# Altium schematic sheet Accel, Pull up&down Res.SchDoc("Accel, Pull up&down Res")
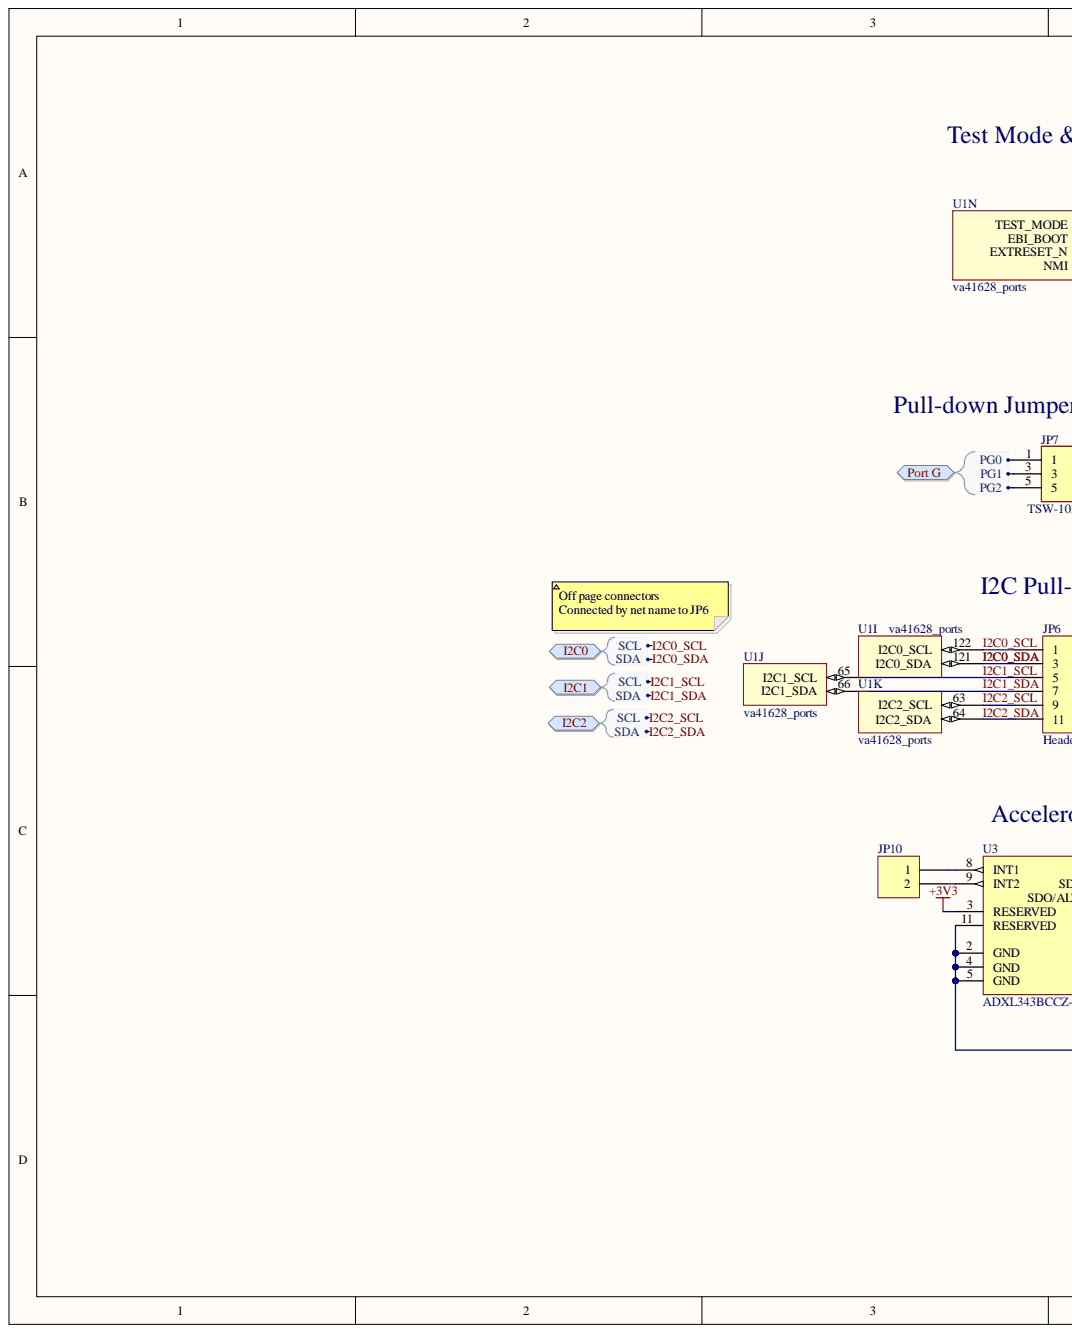
[diagram p0: Accel, Pull up&down Res.SchDoc, left]
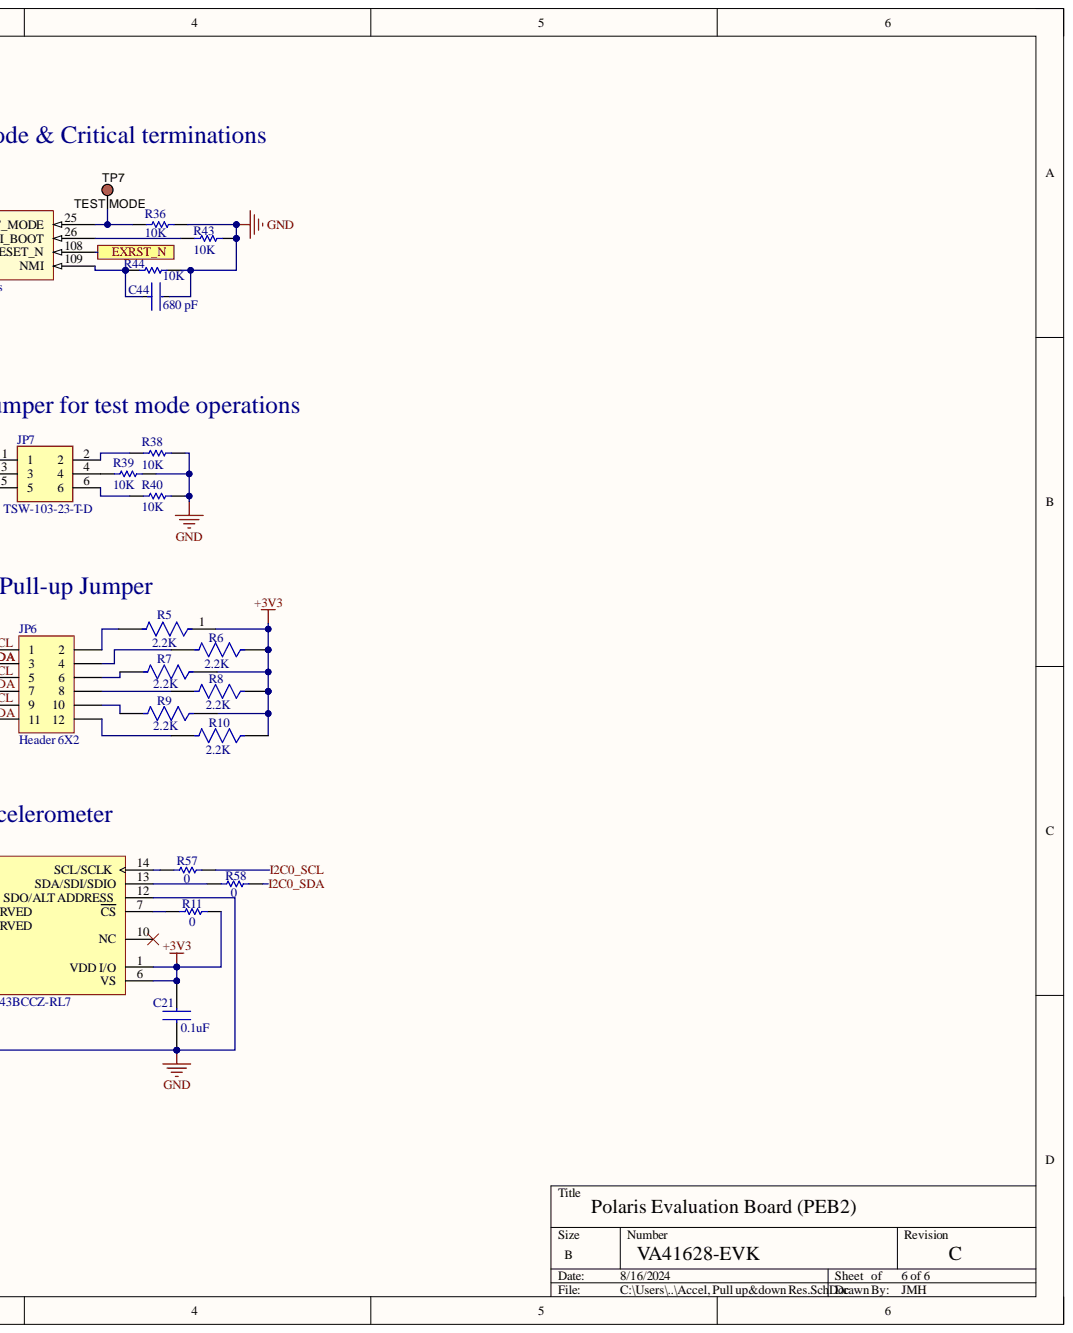
[diagram p1: Accel, Pull up&down Res.SchDoc, right]

## Parts
C21  0.1uF  [C0603C104K3RAC7013]
C44  680pF  value 680 pF  Capacitance: 680 pF  [C0603C681K5GACTU]
JP6  Header 6X2  [61301221121]
JP7  TSW-103-23-T-D  [61300621121]
JP10  (no value)  [JUMPER HOLES]
R5  Resistance: 2.2K  [CRCW08052K20JNEAC]  (hidden comment: CRCW08052K20FKEA)
R6  Resistance: 2.2K  [CRCW08052K20JNEAC]  (hidden comment: CRCW08052K20FKEA)
R7  Resistance: 2.2K  [CRCW08052K20JNEAC]  (hidden comment: CRCW08052K20FKEA)
R8  Resistance: 2.2K  [CRCW08052K20JNEAC]  (hidden comment: CRCW08052K20FKEA)
R9  Resistance: 2.2K  [CRCW08052K20JNEAC]  (hidden comment: CRCW08052K20FKEA)
R10  Resistance: 2.2K  [CRCW08052K20JNEAC]  (hidden comment: CRCW08052K20FKEA)
R11  0  [0OHM]
R36  10k  [CRCW060310K0FKEAC]
R38  10k  [CRCW060310K0FKEAC]
R39  10k  [CRCW060310K0FKEAC]
R40  10k  [CRCW060310K0FKEAC]
R43  10k  [CRCW060310K0FKEAC]
R44  10k  [CRCW060310K0FKEAC]
R57  0  [0OHM]
R58  0  [0OHM]
TP7  TEST MODE  [TEST PIN]
U1  va41628_ports  [TCQFP-128-0.40-36-C]  (parts I,J,K,N)
U3  ADXL343BCCZ-RL7  [ADI-CC-14-1_V]

## Ports
EXRST_N, I2C0, I2C0.SCL, I2C0.SDA, I2C1, I2C1.SCL, I2C1.SDA, I2C2, I2C2.SCL, I2C2.SDA, Port G, Port G.PG0, Port G.PG1, Port G.PG2

## Nets
+3V3: C21.1 R5.1 R6.1 R7.1 R8.1 R9.1 R10.1 R11.1 U3.1(VDD_I/O) U3.3(RESERVED) U3.6(VS)
GND: C21.2 C44.2 R36.2 R38.1 R39.1 R40.1 R43.2 R44.2 U3.2(GND) U3.4(GND) U3.5(GND) U3.11(RESERVED) U3.12(SDO/ALT_ADDRESS)
EXRST_N: U1.108(EXTRESET_N) ; port EXRST_N
I2C0_SCL: JP6.1 R57.1 U1.122(I2C0_SCL) ; port I2C0
I2C0_SDA: JP6.3 R58.1 U1.121(I2C0_SDA) ; port I2C0
I2C1_SCL: JP6.5 U1.65(I2C1_SCL) ; port I2C1
I2C1_SDA: JP6.7 U1.66(I2C1_SDA) ; port I2C1
I2C2_SCL: JP6.9 U1.63(I2C2_SCL) ; port I2C2
I2C2_SDA: JP6.11 U1.64(I2C2_SDA) ; port I2C2
NetC44_1: C44.1 R44.1 U1.109(NMI)
NetJP6_2: JP6.2 R5.2
NetJP6_4: JP6.4 R6.2
NetJP6_6: JP6.6 R7.2
NetJP6_8: JP6.8 R8.2
NetJP6_10: JP6.10 R9.2
NetJP6_12: JP6.12 R10.2
NetJP7_1: JP7.1 ; port Port G
NetJP7_2: JP7.2 R38.2
NetJP7_3: JP7.3 ; port Port G
NetJP7_4: JP7.4 R39.2
NetJP7_5: JP7.5 ; port Port G
NetJP7_6: JP7.6 R40.2
NetJP10_1: JP10.1 U3.8(INT1)
NetJP10_2: JP10.2 U3.9(INT2)
NetR11_2: R11.2 U3.7(CS)
NetR36_1: R36.1 TP7.1 U1.25(TEST_MODE)
NetR43_1: R43.1 U1.26(EBI_BOOT)
NetR57_2: R57.2 U3.14(SCL/SCLK)
NetR58_2: R58.2 U3.13(SDA/SDI/SDIO)

Not connected: U3 10(NC)

## Library data of the parts
C21 = C0603C104K3RAC7013: Capacitor; Manufacturer_Name: KEMET; Component Kind: Standard; Datasheet Link: https://www.kemet.com/specsheet/C0603C104K3RACTM; Height: 0.95mm; Library Name: SamacSys.SchLib; Manufacturer_Part_Number: C0603C104K3RAC7013; Parameter 1: 0.1uF
C44 = CMP-2006-03561-2: CAP CER 680PF 50V NP0 0603; Manufacturer: KEMET; Automotive Grade: Grade 1; Material: Ceramic; Temperature Characteristic: C0G; Temperature Coefficient: 30ppm/°C; Tolerance: 0.1; Automotive: Yes; Category: Cap; Component Kind: Standard; ComponentLink2Description: Datasheet; ComponentLink2URL: https://api.kemet.com/component-edge/download/datasheet/C0603C100J5GACAUTO.pdf; Device Class L1: Passive Components; Device Class L2: Capacitors; Device Class L3: Ceramic Capacitors; Digikey Description: CAP CER 680PF 50V NP0 0603; Height: 0.87mm; Lead Free: Yes; Library Name: Altium Content Vault; Manufacturer Part Number: C0603C681K5GACTU; Mouser Description: Multilayer Ceramic Capacitors MLCC - SMD/SMT 50volts 680pF C0G 10%; Octopart: https://octopart.com/c0603c681k5gactu-kemet-12577598; Package: C0603C-CF; RoHS: TRUE; Temperature: -55°C to +125°C; Temperature Range High: +125°C; Temperature Range Low: -55°C; Voltage Rating: 50 V
JP6 = Header 6X2: Header, 6-Pin, Dual row; Component Kind: Standard; LatestRevisionDate: 17-Jul-2002; LatestRevisionNote: Re-released for DXP Platform.; Library Name: Miscellaneous Connectors.IntLib; Signal Integrity: Connector; Publisher: Altium Limited
JP7 = TSW-103-23-T-D: Connector; Manufacturer_Name: SAMTEC; Arrow Part Number: TSW-103-23-T-D; Arrow Price/Stock: https://www.arrow.com/en/products/tsw-103-23-t-d/samtec?region=nac; Component Kind: Standard; Datasheet Link: http://suddendocs.samtec.com/catalog_english/tsw_th.pdf; Height: 8.38mm; Manufacturer_Part_Number: TSW-103-23-T-D; Mouser Part Number: 200-TSW10323TD; Mouser Price/Stock: https://www.mouser.co.uk/ProductDetail/Samtec/TSW-103-23-T-D?qs=fnpEE5GccuPMhympUnsARw%3D%3D
JP10 = Header 2H: Header, 2-Pin, Right Angle; Component Kind: Standard; LatestRevisionDate: 17-Jul-2002; LatestRevisionNote: Re-released for DXP Platform.; Library Name: Miscellaneous Connectors.IntLib; Signal Integrity: Connector; Publisher: Altium Limited
R5-R10 = CMP-2001-03760-2: RES Thick Film, 2.2kΩ, 1%, 0.125W, 100ppm/°C, 0805; Max Power Dissipation: 125mW; Voltage Rating: 150V; Case Code (Imperial): 0805; Case Code (Metric): 2012; Case/Package: 0805; Component Kind: Standard; Composition: Thick Film; Contact Plating: Tin; Depth: 1.25mm; Features: Automotive AEC-Q200; Height: 0.45mm; Lead Free: Lead Free; Length: 2mm; Library Name: Altium Content Vault; Max Operating Temperature: 155°C; Military Standard: Not; Min Operating Temperature: -55°C; Number of Terminations: 2; Package Quantity: 10; Packaging: Tape and Reel; Power Rating: 125mW; Radiation Hardening: No; Temperature Coefficient: 100ppm/°C; Termination: SMD/SMT; Tolerance: 1%; Voltage Rating (DC): 150V; Weight: 0.000194oz; Width: 1.25mm
R11 R57 R58 = CMP-2000-03816-5: Chip Resistor, 0 Ohm, Jumper, 100 mW, -55 to 155 degC, 0603 (1608 Metric), RoHS, Tape and Reel; Manufacturer: Vishay Semiconductor; Voltage Rating: 75 V; Component Kind: Standard; ComponentLink1Description: Manufacturer URL; ComponentLink1URL: http://www.vishay.com/; ComponentLink2Description: Datasheet; ComponentLink2URL: http://www.vishay.com/docs/20035/dcrcwe3.pdf; DatasheetVersion: 06/2012; Height: 0.45 mm; Length: 1.55 mm; Library Name: Altium Content Vault; Max Operating Temperature: 155 degC; Min Operating Temperature: -55 degC; Mount: Surface Mount; Mounting Technology: Surface Mount; Package Quantity: 5000; PackageDescription: 2-Pin Surface Mount Device, Body 1.575 x 0.85 mm; PackageReference: 0603; Packaging: Tape and Reel; Parameter 1: 0; Pins: 2; REACH SVHC: Unknown; RoHSCompliant: true
R36 R38-R40 R43 R44 = CMP-1666-00005-4: Chip Resistor, 10 KOhm, +/- 1%, 100 mW, -55 to 155 degC, 0603 (1608 Metric), RoHS, Tape and Reel; Manufacturer: Vishay Semiconductor; Voltage Rating: 75 V; Component Kind: Standard; ComponentLink1Description: Manufacturer URL; ComponentLink1URL: http://www.vishay.com/; ComponentLink2Description: Datasheet; ComponentLink2URL: https://datasheet.ciiva.com/11809/0900766b80d98afc-11809037.pdf; DatasheetVersion: 06/2012; Height: 0.45 mm; Length: 1.55 mm; Library Name: Altium Content Vault; Max Operating Temperature: 155 degC; Min Operating Temperature: -55 degC; Mounting Technology: Surface Mount; Package Quantity: 5000; PackageDescription: 2-Pin Surface Mount Device, Body 1.575 x 0.85 mm; PackageReference: 0603; Packaging: Tape and Reel; Parameter 1: 10K; REACH SVHC: Unknown; RoHSCompliant: true
TP7 = CMP-002-00037-2: Test Point, Orange, Height 4.6 mm, Tail Length 3 mm, 1-Pin THD, RoHS; Manufacturer: Keystone; Case/Package: 5003; Colour: Orange; Component Kind: Standard; Connector Type: TestPoint; Contact Material: Bronze; Contact Plating: Tin; Datasheet URL: http://keyelco.com/userAssets/file/M65p56.pdf; Datasheet Version: Jun-15; Diameter: 2.54mm; Manufacturer URL: http://www.keyelco.com/; Mounting Technology: TH; Package Description: 1-Pin Through Hole Device, Dia 2.54 mm; Package Quantity: 10; Package Reference: 5003; Packaging: Bulk; Pins: 1; RoHS Compliant: True; Library Name: Vorago Tech
U1 = va41628_ports: Polaris Lite 128 pin; Component Kind: Standard; Library Name: va416xx.SchLib
U3 = CMP-0885-00026-1: 3-Axis, +/-2 g / +/-4 g / +/-8 g / +/-16 g Digital MEMS Accelerometer with Digital Output, 1.6 KHz BW, Industrial, 14-pin LGA, Reel; Manufacturer: Analog Devices; # of Axes: 3; -3dB Bandwidth: 1.6 KHz; Sensitivity: 256/128/64/32 LSB/g; Voltage Supply (V): 2.0 to 3.6; Component Kind: Standard; ComponentLink1Description: Manufacturer URL; ComponentLink1URL: http://www.analog.com/en/index.html; ComponentLink2Description: Datasheet; ComponentLink2URL: http://www.analog.com/static/imported-files/data_sheets/ADXL343.pdf; ComponentLink3Description: Package Specification; ComponentLink3URL: http://www.analog.com/static/imported-files/data_sheets/ADXL343.pdf; ComponentLink4Description: Product URL; ComponentLink4URL: http://www.analog.com/en/mems-sensors/mems-inertial-sensors/adxl343/products/product.html; DatasheetVersion: Rev. 0; Library Name: Altium Content Vault; Mounting Technology: Surface Mount; Output Type: Digital; PackageDescription: 14-Pin Land Grid Array, 3 x 5 mm Body, 0.8 mm Pitch; PackageReference: CC-14-1; PackageVersion: 03-16-2010-A, 03/2010; Packing: Reel; PartNumber: ADXL343BCCZ-RL7
